# Altium schematic sheet DIGITAL_IO.SchDoc
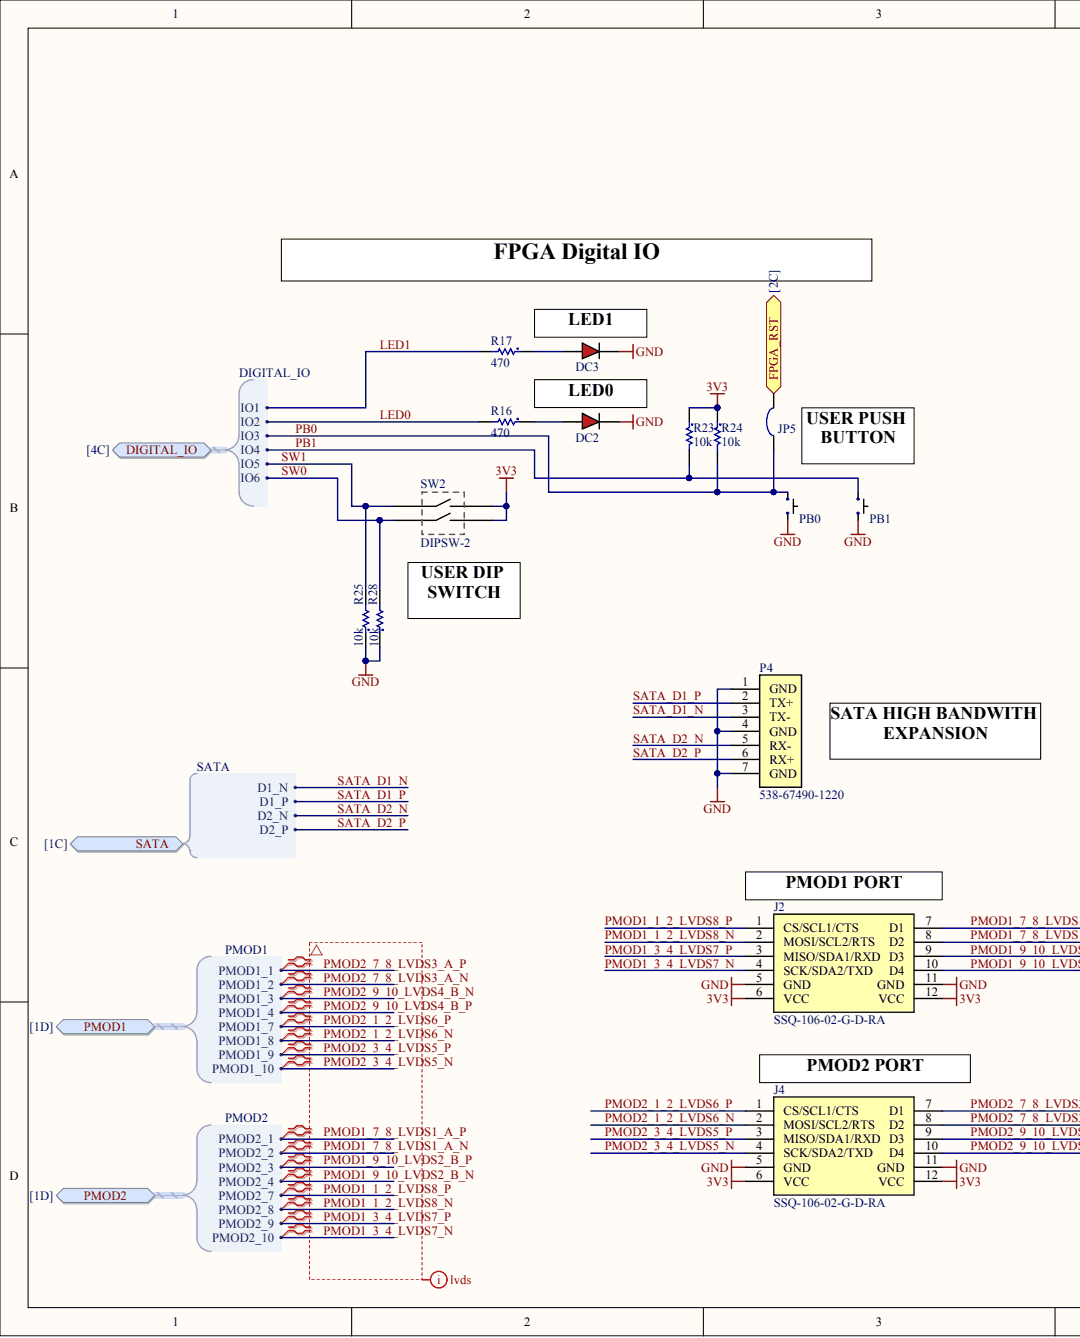
[diagram p0: DIGITAL_IO.SchDoc, left]
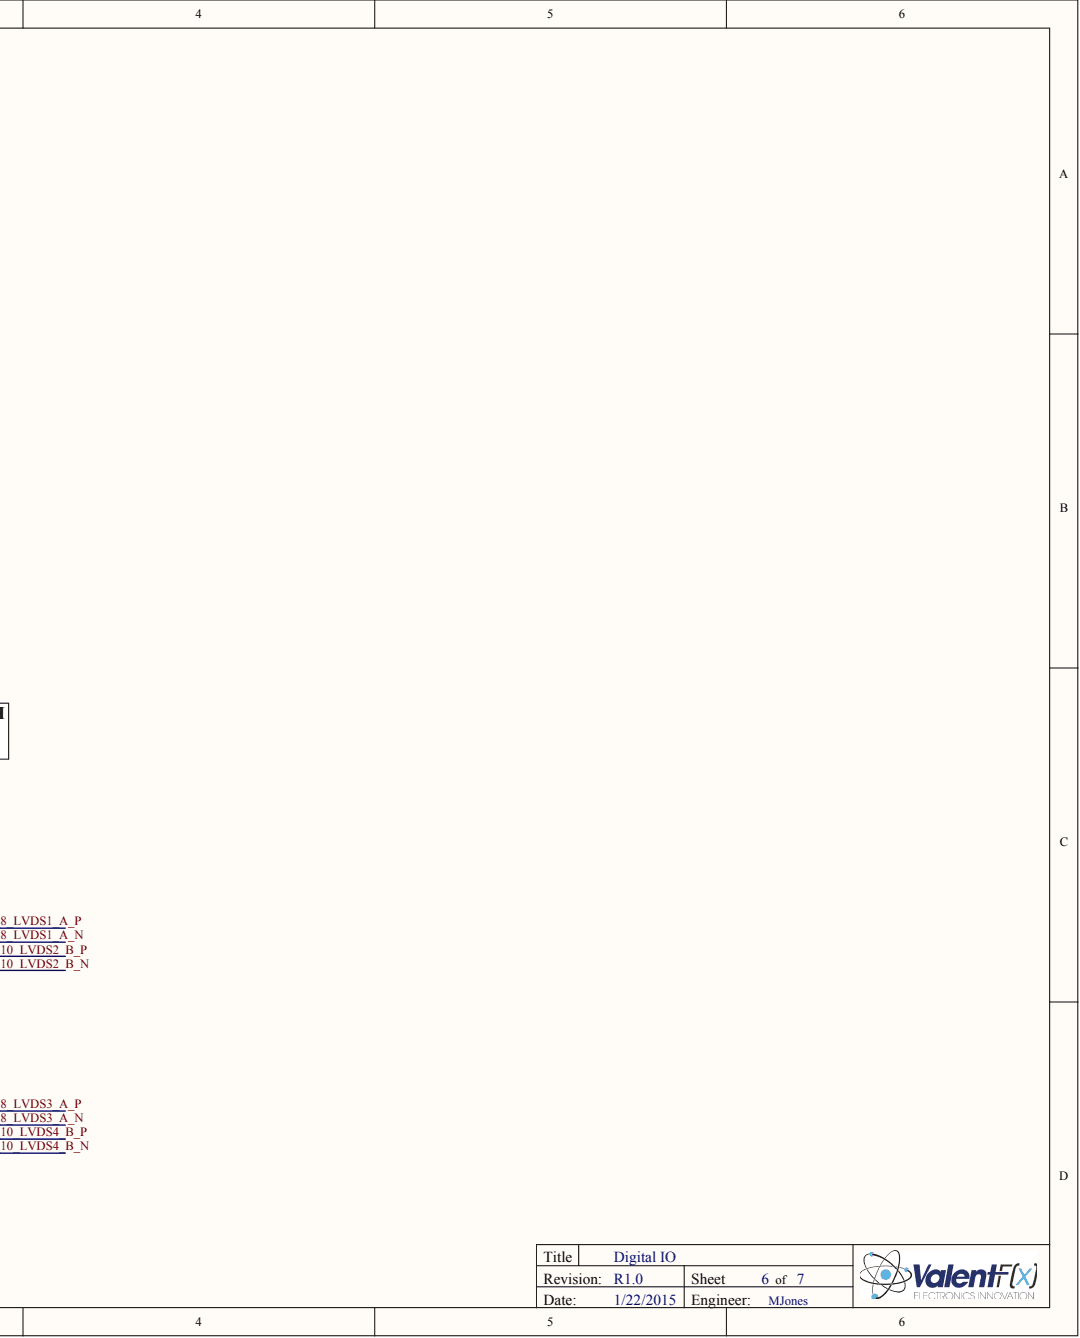
[diagram p1: DIGITAL_IO.SchDoc, right]

## Parts
DC2  598-8010-107F  [LED_0603 - RED]  (value not drawn)
DC3  598-8010-107F  [LED_0603 - RED]  (value not drawn)
J2  SSQ-106-02-G-D-RA  [PMOD HEADER - SSQ-106-02-G-D-RA]
J4  SSQ-106-02-G-D-RA  [PMOD HEADER - SSQ-106-02-G-D-RA]
JP5  Solder Jumper  [SOLDER_JUMPER]  (value not drawn)  (no BOM)
P4  Supplier Part Number 1: 538-67490-1220  [SATA - 67490-3220]  (hidden comment: SATA - 67490-1220)
PB0  Value  [FSM4JSMA]  (value not drawn)
PB1  Value  [FSM4JSMA]  (value not drawn)
R16  470  [R0603]
R17  470  [R0603]
R23  10k  [R0603]
R24  10k  [R0603]
R25  10k  [R0603]
R28  10k  [R0603]
SW2  DIPSW-2  Switch Type: DIP  [DIPSW - 219-2MST]

## Ports
DIGITAL_IO, DIGITAL_IO.IO1, DIGITAL_IO.IO2, DIGITAL_IO.IO3, DIGITAL_IO.IO4, DIGITAL_IO.IO5, DIGITAL_IO.IO6, FPGA_RST, PMOD1, PMOD1.PMOD1_1, PMOD1.PMOD1_2, PMOD1.PMOD1_3, PMOD1.PMOD1_4, PMOD1.PMOD1_7, PMOD1.PMOD1_8, PMOD1.PMOD1_9, PMOD1.PMOD1_10, PMOD2, PMOD2.PMOD2_1, PMOD2.PMOD2_2, PMOD2.PMOD2_3, PMOD2.PMOD2_4, PMOD2.PMOD2_7, PMOD2.PMOD2_8, PMOD2.PMOD2_9, PMOD2.PMOD2_10, SATA, SATA.D1_N, SATA.D1_P, SATA.D2_N, SATA.D2_P

## Nets
3V3: J2.6(VCC) J2.12(VCC) J4.6(VCC) J4.12(VCC) R23.1 R24.1 SW2.B1 SW2.B2
GND: DC2.C DC3.C J2.5(GND) J2.11(GND) J4.5(GND) J4.11(GND) P4.1(GND) P4.4(GND) P4.7(GND) PB0.A PB1.A R25.1 R28.1
FPGA_RST: JP5.2 ; port FPGA_RST
LED0: R16.2 ; port DIGITAL_IO
LED1: R17.2 ; port DIGITAL_IO
PB0: JP5.1 PB0.B R24.2 ; port DIGITAL_IO
PB1: PB1.B R23.2 ; port DIGITAL_IO
PMOD1_1_2_LVDS8_N: J2.2(MOSI/SCL2/RTS) ; port PMOD2
PMOD1_1_2_LVDS8_P: J2.1(CS/SCL1/CTS) ; port PMOD2
PMOD1_3_4_LVDS7_N: J2.4(SCK/SDA2/TXD) ; port PMOD2
PMOD1_3_4_LVDS7_P: J2.3(MISO/SDA1/RXD) ; port PMOD2
PMOD1_7_8_LVDS1_A_N: J2.8(D2) ; port PMOD2
PMOD1_7_8_LVDS1_A_P: J2.7(D1) ; port PMOD2
PMOD1_9_10_LVDS2_B_N: J2.10(D4) ; port PMOD2
PMOD1_9_10_LVDS2_B_P: J2.9(D3) ; port PMOD2
PMOD2_1_2_LVDS6_N: J4.2(MOSI/SCL2/RTS) ; port PMOD1
PMOD2_1_2_LVDS6_P: J4.1(CS/SCL1/CTS) ; port PMOD1
PMOD2_3_4_LVDS5_N: J4.4(SCK/SDA2/TXD) ; port PMOD1
PMOD2_3_4_LVDS5_P: J4.3(MISO/SDA1/RXD) ; port PMOD1
PMOD2_7_8_LVDS3_A_N: J4.8(D2) ; port PMOD1
PMOD2_7_8_LVDS3_A_P: J4.7(D1) ; port PMOD1
PMOD2_9_10_LVDS4_B_N: J4.10(D4) ; port PMOD1
PMOD2_9_10_LVDS4_B_P: J4.9(D3) ; port PMOD1
SATA_D1_N: P4.3(TX-) ; port SATA
SATA_D1_P: P4.2(TX+) ; port SATA
SATA_D2_N: P4.5(RX-) ; port SATA
SATA_D2_P: P4.6(RX+) ; port SATA
SW0: R28.2 SW2.A2 ; port DIGITAL_IO
SW1: R25.2 SW2.A1 ; port DIGITAL_IO
NetDC2_A: DC2.A R16.1
NetDC3_A: DC3.A R17.1
N00155:

## Library data of the parts
DC2 DC3 = LED0603 - 598-8010-107F: LED ALINGAP RED CLEAR 0603 SMD; Manufacturer: Dialight; Accept Replacement: YES - Water Clear; Current - Test: 20mA; Lens Style/Size: Rectangle with Flat Top, 1.1mm x 0.8mm; Millicandela Rating: 40mcd; Viewing Angle: 140°; Voltage - Forward (Vf) Typ: 2.2V; Wavelength - Dominant: 635nm; Category: Optoelectronics; Color: Red; Component Kind: Standard; ComponentLink1Description: http://media.digikey.com/pdf/Data%20Sheets/Dialight%20PDFs/598_Series_0603_Pkg.pdf; ComponentLink1URL: http://media.digikey.com/pdf/Data%20Sheets/Dialight%20PDFs/598_Series_0603_Pkg.pdf; Height: 0.70mm; Lens Type: Clear; Library Name: mDiode.SchLib; Luminous Flux @ Current - Test: -; Manufacturer Part Number: 598-8010-107F; Mounting Type: Surface Mount; Package / Case: 0603 (1608 Metric); Packaging: Digi-Reel®; Pricing 1: 1=0.45, 10=0.33, 25=0.264, 100=0.1749, 250=0.1452, 500=0.1056, 1000=0.0825 (USD); RoHS: RoHS Compliant; Size / Dimension: 1.60mm L x 0.80mm W; Stock 1: 37578; Supplier 1: Digi-Key; Supplier Part Number 1: 350-2029-6-ND; Wavelength - Peak: -
J2 J4 = P-MOD - SSQ-106-02-G-D-RA: SAMTEC - SSQ-106-02-G-D-RA-005 - 2MM SOCKET STRIPS; Component Kind: Standard; Library Name: mConnector.SchLib; Manufacturer: SAMTEC; Manufacturer Part Number: SSQ-106-02-G-D-RA; Pricing 1: 1=1.58, 100=1.58, 1000=1.58 (USD); Stock 1: 0; Supplier 1: Samtec; Supplier Part Number 1: SSQ-106-02-G-D-RA; Value: SSQ-106-02-G-D-RA
JP5 = Solder Jumper: Solder/Configuration Jumper; Library Name: mConnector.SchLib; Manufacturer: n/a; Manufacturer Part Number: n/a; ROHS Certificate: n/a; Source: n/a; Source Part Number: n/a
P4 = SATA - 67490-1220: SATA Data - 67490-1220; Manufacturer: Molex; 1Notes: looks more standard need, different footprint; Gender: Male; Number of Positions / Contacts: 7; Pitch: 1.27 mm; Category: I/O Connectors; Component Kind: Standard; ComponentLink1Description: http://www.molex.com/webdocs/datasheets/pdf/en-us/0674901220_PCB_HEADERS.pdf; ComponentLink1URL: http://www.molex.com/webdocs/datasheets/pdf/en-us/0674901220_PCB_HEADERS.pdf; Library Name: mConnector.SchLib; Manufacturer Part Number: 67490-1220; Minimum Order Quantity: 1; Mounting Style: SMD/SMT; Packaging: Tray; Pricing 1: 1=1.28, 10=1.07, 100=0.792, 500=0.640, 1000=0.572, 2000=0.525 (AUD); Product: SATA Connectors; Product Category: 1.27mm Connectors; Reeling: False; RoHS: RoHS Compliant; RoHS - Mouser: Y; Standard Pack Qty: 2880; Stock 1: 475; Supplier 1: Mouser; Termination Style: Solder Terminal
PB0 PB1 = FSM4JSMATR: SWITCH TACTILE SPST-NO 0.05A 12V; Manufacturer: TE Connectivity; Actuator Height off PCB, from Pins: 5.00mm; Actuator Material: Polyphenylene Sulfide (PPS); Actuator Orientation: Top Actuated; Actuator Type: Standard; Contact Rating @ Voltage: 0.05A @ 12VDC; Illumination: Non-Illuminated; Mechanical Life: 100,000 Cycles; Operating Force: 160gf; Outline: 6.00mm x 6.00mm; Switch Function: Off-Mom; Switch Travel: 0.25mm; Category: Switches; Circuit: SPST-NO; Component Kind: Standard; ComponentLink1Description: http://www.te.com/commerce/DocumentDelivery/DDEController?Action=srchrtrv&DocNm=2-1437565-7&DocType=Customer+Drawing&DocLang=English; ComponentLink1URL: http://www.te.com/commerce/DocumentDelivery/DDEController?Action=srchrtrv&DocNm=2-1437565-7&DocType=Customer+Drawing&DocLang=English; Features: -; Illumination Type, Color: -; Illumination Voltage (Nominal): -; Ingress Protection: -; Library Name: mElectroMechanical.SchLib; Manufacturer Part Number: FSM4JSMA; Mounting Type: Surface Mount; Operating Temperature: -35°C ~ 85°C; Packaging: Bulk; Pricing 1: 1=0.26, 10=0.246, 25=0.2096, 50=0.1834, 100=0.1703, 250=0.15064, 500=0.1441, 1000=0.1179, 5000=0.09825 (USD); RoHS: RoHS Compliant; Stock 1: 13038; Supplier 1: Digi-Key; Supplier Part Number 1: 450-1129-ND; Termination Style: Gull Wing
R16 R17 = R0603 - CRCW0603470RJNEA: RES 470 OHM 1/10W 5% 0603 SMD; Manufacturer: Vishay Dale; Power (Watts): 0.1W, 1/10W; Temperature Coefficient: ±200ppm/°C; Category: Resistors; Component Kind: Standard; ComponentLink1Description: http://www.vishay.com/docs/20035/dcrcwe3.pdf; ComponentLink1URL: http://www.vishay.com/docs/20035/dcrcwe3.pdf; Composition: Thick Film; Features: Automotive AEC-Q200 Qualified; Height: 0.020" (0.50mm); Library Name: mResistors.SchLib; Manufacturer Part Number: CRCW0603470RJNEA; Number of Terminations: 2; Operating Temperature: -55°C ~ 155°C; Package / Case: 0603 (1608 Metric); Packaging: Digi-Reel®; Pricing 1: 10=0.08, 50=0.0432, 200=0.0248, 1000=0.01694 (USD); Resistance (Ohms): 470; RoHS: RoHS Compliant; Size / Dimension: 0.061" L x 0.033" W (1.55mm x 0.85mm); Stock 1: 83706; Supplier 1: Digi-Key; Supplier Device Package: 0603 (1608 Metric); Supplier Part Number 1: 541-470GDKR-ND; Tolerance: ±5%
R23-R25 R28 = R0603 - CRCW060310K0FKEA: 10k Thick Film Resistors - SMD 1/10watt 10Kohms 1%; Manufacturer: Vishay Dale; Power (Watts): 0.1W, 1/10W; Temperature Coefficient: ±100ppm/°C; Category: Resistors; Component Kind: Standard; ComponentLink1Description: http://www.vishay.com/doc?20035; ComponentLink1URL: http://www.vishay.com/doc?20035; Composition: Thick Film; Features: -; Height: 0.020" (0.50mm); Library Name: mResistors.SchLib; Manufacturer Part Number: CRCW060310K0FKEA; Number of Terminations: 2; Package / Case: 0603 (1608 Metric); Packaging: Cut Tape (CT); Pricing 1: 10=0.081, 50=0.0436, 200=0.0251, 1000=0.01711 (USD); Resistance (Ohms): 10K; RoHS: RoHS Compliant; Size / Dimension: 0.061" L x 0.033" W (1.55mm x 0.85mm); Stock 1: 997856; Supplier 1: Digi-Key; Supplier Part Number 1: 541-10.0KHCT-ND; Tolerance: ±1%; Value: 10k
SW2 = DIPSW - 219-2MST: DIP Switches / SIP Switches SPST 2 switch sections; Manufacturer: CTS Electronic Components; Number of Positions: 2; Category: DIP Switches / SIP Switches; Component Kind: Standard; ComponentLink1Description: http://www.ctscorp.com/components/Datasheets/219.pdf; ComponentLink1URL: http://www.ctscorp.com/components/Datasheets/219.pdf; Library Name: mElectroMechanical.SchLib; Manufacturer Part Number: 219-2MST; Minimum Order Quantity: 1; Operating Temperature Range: - 55 C to + 85 C; Packaging: Tube; Pricing 1: 1=0.580, 10=0.530, 100=0.480, 500=0.350, 1000=0.300, 5000=0.270 (USD); Product Category: DIP Switches; Reeling: False; RoHS: RoHS Compliant; RoHS - Mouser: Y; Stock 1: 3237; Supplier 1: Mouser; Supplier Part Number 1: 774-2192MST; Terminal Pitch: 2.54 mm; Termination Style: SMD/SMT
